# Altium schematic sheet Sheet1.SchDoc
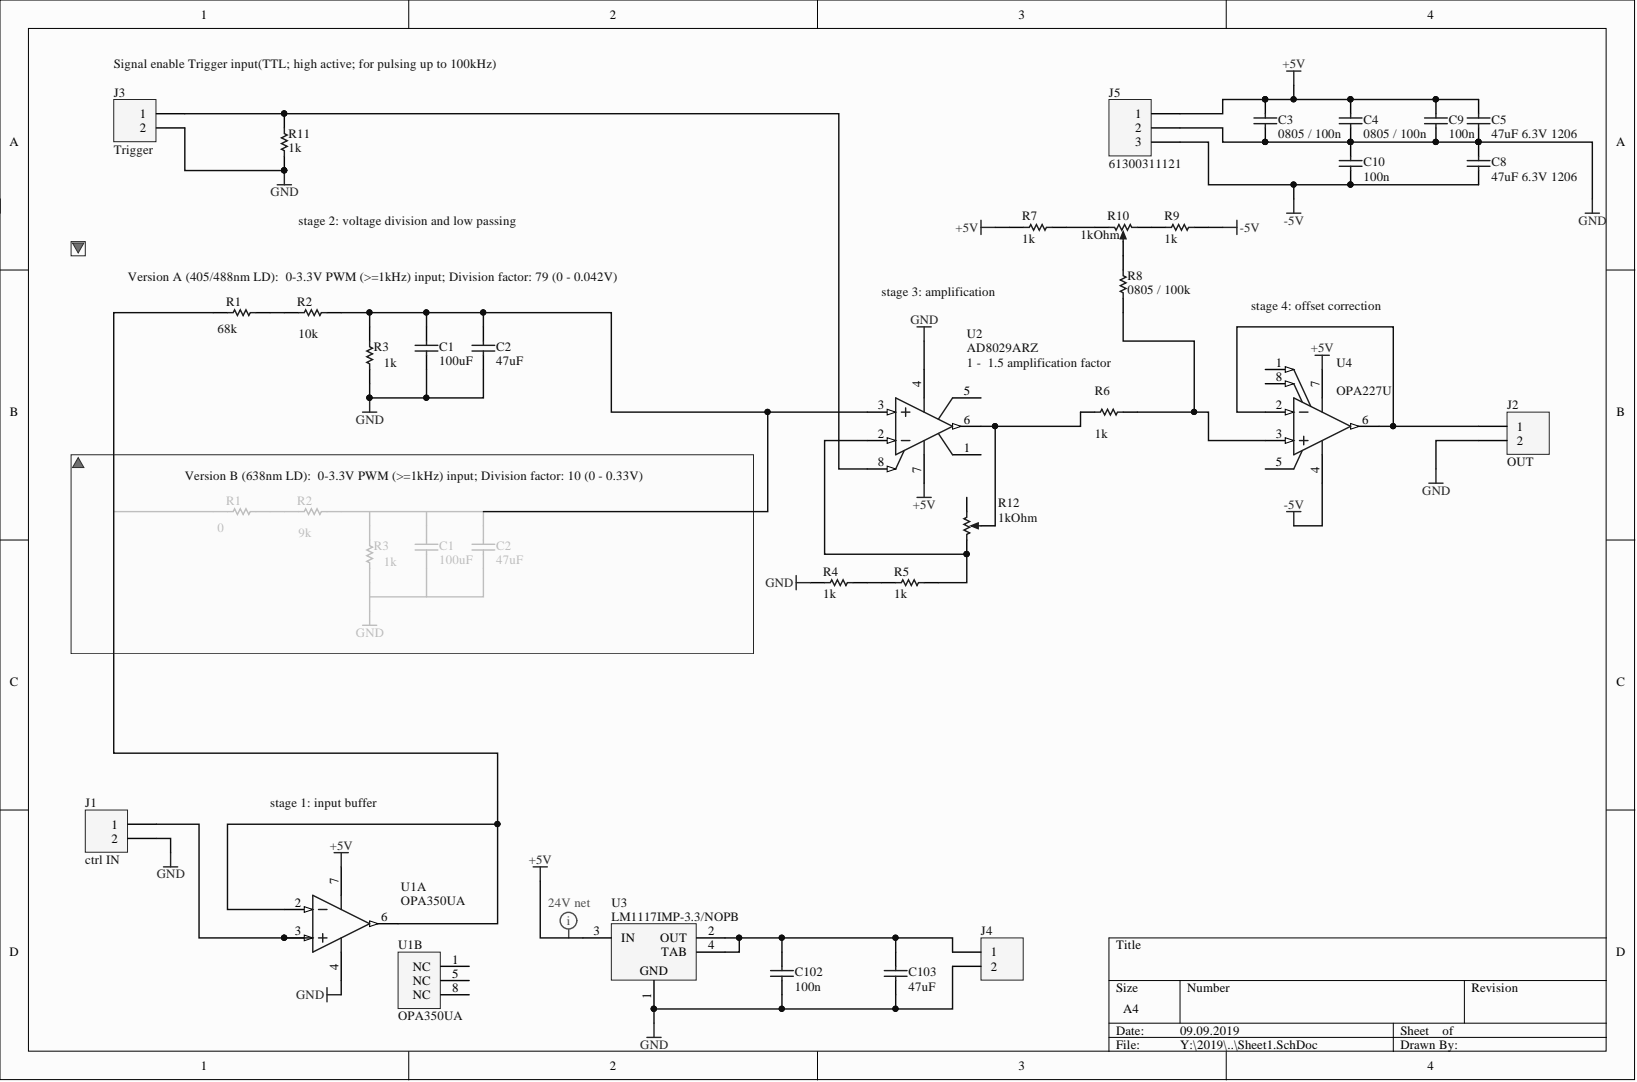
[diagram p0: Sheet1.SchDoc]

## Parts
C1  100uF  [CAPC1206(3216)190_L]
C2  47uF  [CAPC1206(3216)190_M]
C3  0805 / 100n  Case Code - in: 0805  [CAPC2013X88X50LL20T25]
C4  0805 / 100n  Case Code - in: 0805  [CAPC2013X88X50LL20T25]
C5  47uF 6.3V 1206  value 47uF  [CAPC1206(3216)190_M]
C8  47uF 6.3V 1206  value 47uF  [CAPC1206(3216)190_M]
C9  100n  [CAPC2013X88X50ML20T25]
C10  100n  [CAPC2013X88X50ML20T25]
C102  100n  [CAPC2013X88X50ML20T25]
C103  47uF  [CAPC1206(3216)190_M]
J1  ctrl IN  [61300211121]
J2  OUT  [61300211121]
J3  Trigger  [61300211121]
J4  potentiometer connect  [61300211121]  (value not drawn)
J5  61300311121  [61300311121]
R1  68k  [YAGO-RC0805_M]
R2  10k  [YAGO-RC0805_M]
R3  1k  [YAGO-RC0805_M]
R4  1k  [YAGO-RC0805_M]
R5  1k  [YAGO-RC0805_M]
R6  1k  [YAGO-RC0805_M]
R7  1k  [YAGO-RC0805_M]
R8  0805 / 100k  Supplier Device Package: 0805  [YAGO-RC0805_M]
R9  1k  [YAGO-RC0805_M]
R10  1kOhm  [Typ 3296Y]
R11  1k  [YAGO-RC0805_M]
R12  1kOhm  [Typ 3296Y]
U1  OPA350UA  [D0008A_M]  (parts A,B)
U2  AD8029ARZ  [ADI-R-8_L]
U3  LM1117IMP-3.3/NOPB  [DCY0004A_N]
U4  OPA227U  [D0008A_M]

## Nets
+5V: C3.2 C4.2 C5.2 C9.2 J5.1 R7.2 U1.7 U2.7 U3.3(IN) U4.7
-5V: C8.1 C10.1 J5.3 R9.1 U4.4
GND: C1.1 C2.1 C3.1 C4.1 C5.1 C8.2 C9.1 C10.2 C102.1 C103.1 J1.2 J2.2 J3.2 J4.2 J5.2 R3.1 R4.2 R11.2 U1.4 U2.4 U3.1
NetC1_2: C1.2 C2.2 R2.2 R3.2 U2.3
NetC102_2: C102.2 C103.2 J4.1 U3.2(OUT) U3.4(TAB)
NetJ1_1: J1.1 U1.3
NetJ2_1: J2.1 U4.2 U4.6
NetJ3_1: J3.1 R11.1 U2.8
NetR1_1: R1.1 U1.2 U1.6
NetR1_2: R1.2 R2.1
NetR4_1: R4.1 R5.2
NetR5_1: R5.1 R12.3 U2.2
NetR6_1: R6.1 R8.1 U4.3
NetR6_2: R6.2 R12.2 U2.6
NetR7_1: R7.1 R10.3
NetR8_2: R8.2 R10.2
NetR9_2: R9.2 R10.1
N00004:

Not connected: R12 1; U1 1(NC) 5(NC) 8(NC); U2 1 5; U4 1 5 8

## Library data of the parts
C1 = CMP-00469-1: CAP 100uF 6.3V ±20% 1206 (3216 Metric) Thickness 1.9mm SMD; Case-EIA: 1206; Case-Metric: 3216; Tolerance: Â±20%; Component Kind: Standard; Max Thickness: 1.9 mm; Rated Voltage: 6.3 V; Technology: SMT; Value: 100uF; Library Name: Altium Vault EMBL 3.0
C2 C5 C8 C103 = CMP-00470-A.1: CAP 47uF 6.3V ±20% 1206 (3216 Metric) Thickness 1.9mm SMD; Case-EIA: 1206; Case-Metric: 3216; Tolerance: Â±20%; Component Kind: Standard; Max Thickness: 1.9 mm; Rated Voltage: 6.3 V; Technology: SMT
  C2 C103: Value: 47uF
  C2: Library Name: Altium Vault EMBL 3.0
  C5 C8 C103: Library Name: Altium Vault EMBL
C3 C4 = CMP-001-0082-1: generic_0805_example here 100nF: C0805C104K5RAC 7800 SMD-Keramik-Vielschichtkondensator 100 nF, 50 VDC, 0805, ±10 %, X7R; Brand: KEMET; Capacitance: 0.1 uF; Capacitance - nF: 100 nF; Capacitance - pF: 100000 pF; Class: Class 1; Tolerance: 10 %; Voltage Rating DC: 50 VDC; Case Code - mm: 2012; Component Kind: Standard; ComponentLink1Description: KEM_C1002_X7R_SMD-1102033.pdf; ComponentLink1URL: http://www.mouser.com/ds/2/212/KEM_C1002_X7R_SMD-1102033.pdf; Dielectric: X7R; Height: -; Length: 2 mm; Manufacturer: KEMET; Manufacturer Part Number: C0805C104K5RAC7800; Maximum Operating Temperature: + 125 C; Minimum Operating Temperature: - 55 C; Minimum Order Quantity: 4000; Package/Case: 0805 (2012 metric); Packaging: Reel; Product: General Type MLCCs; Reeling: True; RoHS - Mouser: Y; Series: C-C; Standard Pack Qty: 4000; Supplier: Mouser; Supplier Part Number: 80-C0805C104K5R7800; Termination: -; Termination Style: SMD/SMT; Voltage Rating AC: -; Width: 1.2 mm; Library Name: Altium Vault EMBL
C9 C10 C102 = CMP-001-0082-2: generic 0805 COG oder X7R Vielschichtkondensatoren max. +/-10%; Component Kind: Standard; Library Name: Altium Vault EMBL
J1-J4 = CMP-001-0071-1: 2Pol; gerade; WR-PHD 2.54 mm THT Pin Header; Brand: Wurth Electronics; Current Rating: 3 A; Insulation Resistance: 1 GOhms; Latching Type: Unlatched; Mating Post Length: 6 mm; Mounting Angle: Straight; Number of Positions: 2 Position; Pitch: 2.54 mm; Voltage Rating: 250 V; Accessory Type: -; Application: Wire to Board; Component Kind: Standard; ComponentLink1Description: 6130xx11121-538006.pdf; ComponentLink1URL: http://www.mouser.com/ds/2/445/6130xx11121-538006.pdf; Contact Gender: Pin (Male); Contact Material: Copper Alloy; Contact Plating: Gold; Contact Type: -; Flammability Rating: UL 94 V-0; Housing Gender: -; Housing Material: Polybutylene Terephthalate (PBT); Manufacturer: Wurth Electronics; Manufacturer Part Number: 61300211121; Minimum Order Quantity: 1; Mounting Style: Straight Pin; Number of Rows: 1 Row; Packaging: Bulk; PartNumber: 61300211121; Product: Headers; Reeling: False; RoHS - Mouser: Y; Row Spacing: -; Series: WR-PHD; Standard Pack Qty: 1000; Supplier: Mouser; Supplier Part Number: 710-61300211121; Termination Post Length: 3 mm; Termination Style: Through Hole; Type: Pin Strip
  J1 J4: Library Name: Altium Vault EMBL 3.0
  J2 J3: Library Name: Altium Vault EMBL
J5 = CMP-001-0072-1: 3Pol; gerade; WR-PHD 2.54 mm THT Pin Header; Brand: Wurth Electronics; Current Rating: 3 A; Insulation Resistance: 1 GOhms; Mating Post Length: 6 mm; Mounting Angle: Straight; Number of Positions: 3 Position; Pitch: 2.54 mm; Voltage Rating: 250 V; Component Kind: Standard; ComponentLink1Description: 6130xx11121-538006.pdf; ComponentLink1URL: http://www.mouser.com/ds/2/445/6130xx11121-538006.pdf; Contact Gender: Pin (Male); Contact Material: Copper Alloy; Contact Plating: Gold; Contact Type: -; Flammability Rating: UL 94 V-0; Housing Gender: -; Housing Material: Polyamide (PA); Manufacturer: Wurth Electronics; Manufacturer Part Number: 61300311121; Minimum Order Quantity: 1; Mounting Style: Straight Pin; Number of Rows: 1 Row; Packaging: Bulk; PartNumber: 61300311121; Product: Headers; Reeling: False; RoHS - Mouser: Y; Row Spacing: -; Series: WR-PHD; Standard Pack Qty: 1000; Supplier: Mouser; Supplier Part Number: 710-61300311121; Termination Post Length: 3 mm; Termination Style: Through Hole; Type: Pin Strip; Library Name: Altium Vault EMBL
R1-R9 R11 = CMP-001-0027-2: 0805 Yageo Standard BlackBox Resistors; Power (Watts): 0.125W, 1/8W; Temperature Coefficient: ±200ppm/°C; Tolerance: ±1%; Component Kind: Standard; ComponentLink1Description: PYu-RC_Group_51_RoHS_L_7.pdf; ComponentLink1URL: http://www.yageo.com/documents/recent/PYu-RC_Group_51_RoHS_L_7.pdf; Composition: Thick Film; Failure Rate: -; Features: Moisture Resistant; Height - Seated (Max): 0.024" (0.60mm); Manufacturer: Yageo; Operating Temperature: -55°C ~ 155°C; Package / Case: 0805 (2012 Metric); Packaging: Digi-Reel®; Part Status: Active; Size / Dimension: 0.079" L x 0.049" W (2.00mm x 1.25mm)
  R1-R7 R9 R11: Supplier Device Package: 0805
  R1-R3: Library Name: Altium Vault EMBL 3.0
  R4-R9 R11: Library Name: Altium Vault EMBL
R10 R12 = CMP-001-0079-1: Trimmer_stehend_rechteckig_Verstellung-von-Seite; Manufacturer Part Number: 3296Y-1-102LF; Adjustment: Top Crossed; Brand: Bourns; Element Type: Cermet; Number of Turns: 25; Power Rating: 500 mW (1/2 W); Resistance: 1 kOhms; Temperature Coefficient: 100 PPM / C; Tolerance: 10 %; Component Kind: Standard; ComponentLink1Description: 296-776415.pdf; ComponentLink1URL: http://www.mouser.com/ds/2/54/296-776415.pdf; Length: 9.53 mm; Manufacturer: Bourns; Minimum Order Quantity: 1; Mounting: Panel Mount; Operating Temperature Range: - 55 C to + 150 C; Packaging: Tube; PartNumber: 3296Y-1-102LF; Product: Multiturn; Product Type: Square Trimming Potentiometer; Reeling: False; RoHS - Mouser: E; Series: 3296; Standard Pack Qty: 100; Supplier: Mouser; Supplier Part Number: 652-3296Y-1-102LF; Taper: Linear; Termination Style: Pin; Tradename: Trimpot; Type: Trimmers; Width: 9.53 mm; Library Name: Altium Vault EMBL
U1 = CMP-00488-1: High-Speed, Single-Supply, Rail-to-Rail Operational Amplifier MicroAmplifier(TM) Series, 2.7 to 5.5 V, -40 to 85 degC, 8-pin SOIC (D8), Green (RoHS & no Sb/Br); Manufacturer: Texas Instruments; CMRR(Min)(dB): 66; IIB(Max)(pA): 10; Iq per channel(Max)(mA): 8.5; Number of Channels: 1; Offset Drift(Typ)(uV/C): 4; Slew Rate(Typ)(V/us): 22; Sub Family: Low Input Bias Current Amp (<=10pA), Low Offset Voltage Amplifier (<=500uV), Low Supply Voltage Amplifier (<=2.7V), Precision Amplifier; Total Supply Voltage(Max)(+5V is 5, +/-5V is 10): 5.5; Total Supply Voltage(Min)(+5V is 5, +/-5V is 10): 2.7; Vn at 1kHz(Typ)(nV/rtHz): 18; Code_JEDEC: MS-012; Component Kind: Standard; ComponentLink1Description: Manufacturer URL; ComponentLink1URL: http://www.ti.com/; ComponentLink2Description: Datasheet; ComponentLink2URL: http://www.ti.com/general/docs/lit/getliterature.tsp?genericPartNumber=OPA350&fileType=pdf; ComponentLink3Description: Package Specification; ComponentLink3URL: http://www.ti.com/litv/pdf/msoi002j; DatasheetVersion: SBOS099C; Eco-Plan: Green (RoHS & no Sb/Br); Mounting Technology: Surface Mount; PackageDescription: 8-Pin Plastic Small Outline, Body 4.9 x 3.9 mm, Pitch 1.27 mm; PackageReference: D0008A; PackageVersion: 4040047-3/M, 06/2011; PartNumber: OPA350UA; Vio (25C)(Max)(mV): 1; Library Name: Altium Vault EMBL
U2 = CMP-00480-1: Single Channel RRIO Operational Amplifier, 125 MHz BW, 62 V/us SR, Industrial, 8-pin SOIC (R-8), Tube; Generic Part Number: AD8029; Manufacturer: Analog Devices; Amplifiers Per Package: 1; Input Bias Current: 700nA; Input Offset Voltage: 1.6mV; Iq/Amp: 1.5mA; Slew Rate: 62V/us; Small Signal Bandwidth: 125MHz; V Span Max: 12V; V Span Min: 2.7V; Code_JEDEC: MS-012-AA; Component Kind: Standard; ComponentLink1Description: Manufacturer URL; ComponentLink1URL: http://www.analog.com/en/index.html; ComponentLink2Description: Datasheet; ComponentLink2URL: http://www.analog.com/static/imported-files/data_sheets/AD8029_8030_8040.pdf; ComponentLink3Description: Package Specification; ComponentLink3URL: http://www.analog.com/static/imported-files/packages/PKG_PDF/SOIC_Narrow%20(R)/R_8.pdf; DatasheetVersion: Rev. B; Mounting Technology: Surface Mount; PackageDescription: 8-Pin Standard Small Outline Package, Body 3.9 x 4.9 mm, Pitch 1.27 mm; PackageReference: R-8; PackageVersion: 060506-A; PartNumber: AD8029ARZ; Library Name: Altium Vault EMBL
U3 = CMP-00484-1: 800mA Low-Dropout Linear Regulator, 4-pin SOT-223, Pb-Free; Manufacturer: Texas Instruments; CompType: LDO IC; High-Reliability: Y; PackageTopMarking: XYTT*N05B; PowerWise: N; Code_IPC: SOT223-4; Code_JEDEC: TO-261-AA; Component Kind: Standard; ComponentLink1Description: Datasheet URL; ComponentLink1URL: http://www.ti.com/general/docs/lit/getliterature.tsp?genericPartNumber=LM1117-N&fileType=pdf; PackageDescription: Molded SOT-223, 6.50 x 3.56 x 1.6mm Body, 4 Lead, 2.3mm Pitch; PackageReference: DCY0004A; PartNumber: LM1117IMP-3.3/NOPB; PinCount: 4; RoHS: Y; Library Name: Altium Vault EMBL
U4 = CMP-00481-1: High Precision, Low Noise Operational Amplifier, 5 to 36 V, -55 to 125 degC, 8-pin SOIC (D8), Green (RoHS & no Sb/Br); Manufacturer: Texas Instruments; CMRR(Min)(dB): 120; GBW(Typ)(MHz): 8; IIB(Max)(pA): 10000; Iq per channel(Max)(mA): 3.8; Number of Channels: 1; Offset Drift(Typ)(uV/C): 0.1; Packing: TUBE; Rating: Catalog; Slew Rate(Typ)(V/us): 2.3; Sub Family: High Supply Voltage Amplifier (>=30V), Low Noise Amp (<=10nV/rtHz), Low Offset Voltage Amplifier (<=500uV), Precision Amplifier; Total Supply Voltage(Max)(+5V is 5, +/-5V is 10): 36; Total Supply Voltage(Min)(+5V is 5, +/-5V is 10): 5; Vio (25C)(Max)(mV): 0.075; Code_JEDEC: MS-012; Component Kind: Standard; ComponentLink1Description: Manufacturer URL; ComponentLink1URL: http://www.ti.com/; ComponentLink2Description: Datasheet; ComponentLink2URL: http://www.ti.com/lit/ds/symlink/opa227.pdf; ComponentLink3Description: Package Specification; ComponentLink3URL: http://www.ti.com/litv/pdf/msoi002j; DatasheetVersion: SBOS110A; Eco-Plan: Green (RoHS & no Sb/Br); Mounting Technology: Surface Mount; PackageDescription: 8-Pin Plastic Small Outline, Body 4.9 x 3.9 mm, Pitch 1.27 mm; PackageReference: D0008A; PackageVersion: 4040047-3/M, 06/2011; PartNumber: OPA227U; Library Name: Altium Vault EMBL
